# Altium schematic sheet Connector.SchDoc(Connector)
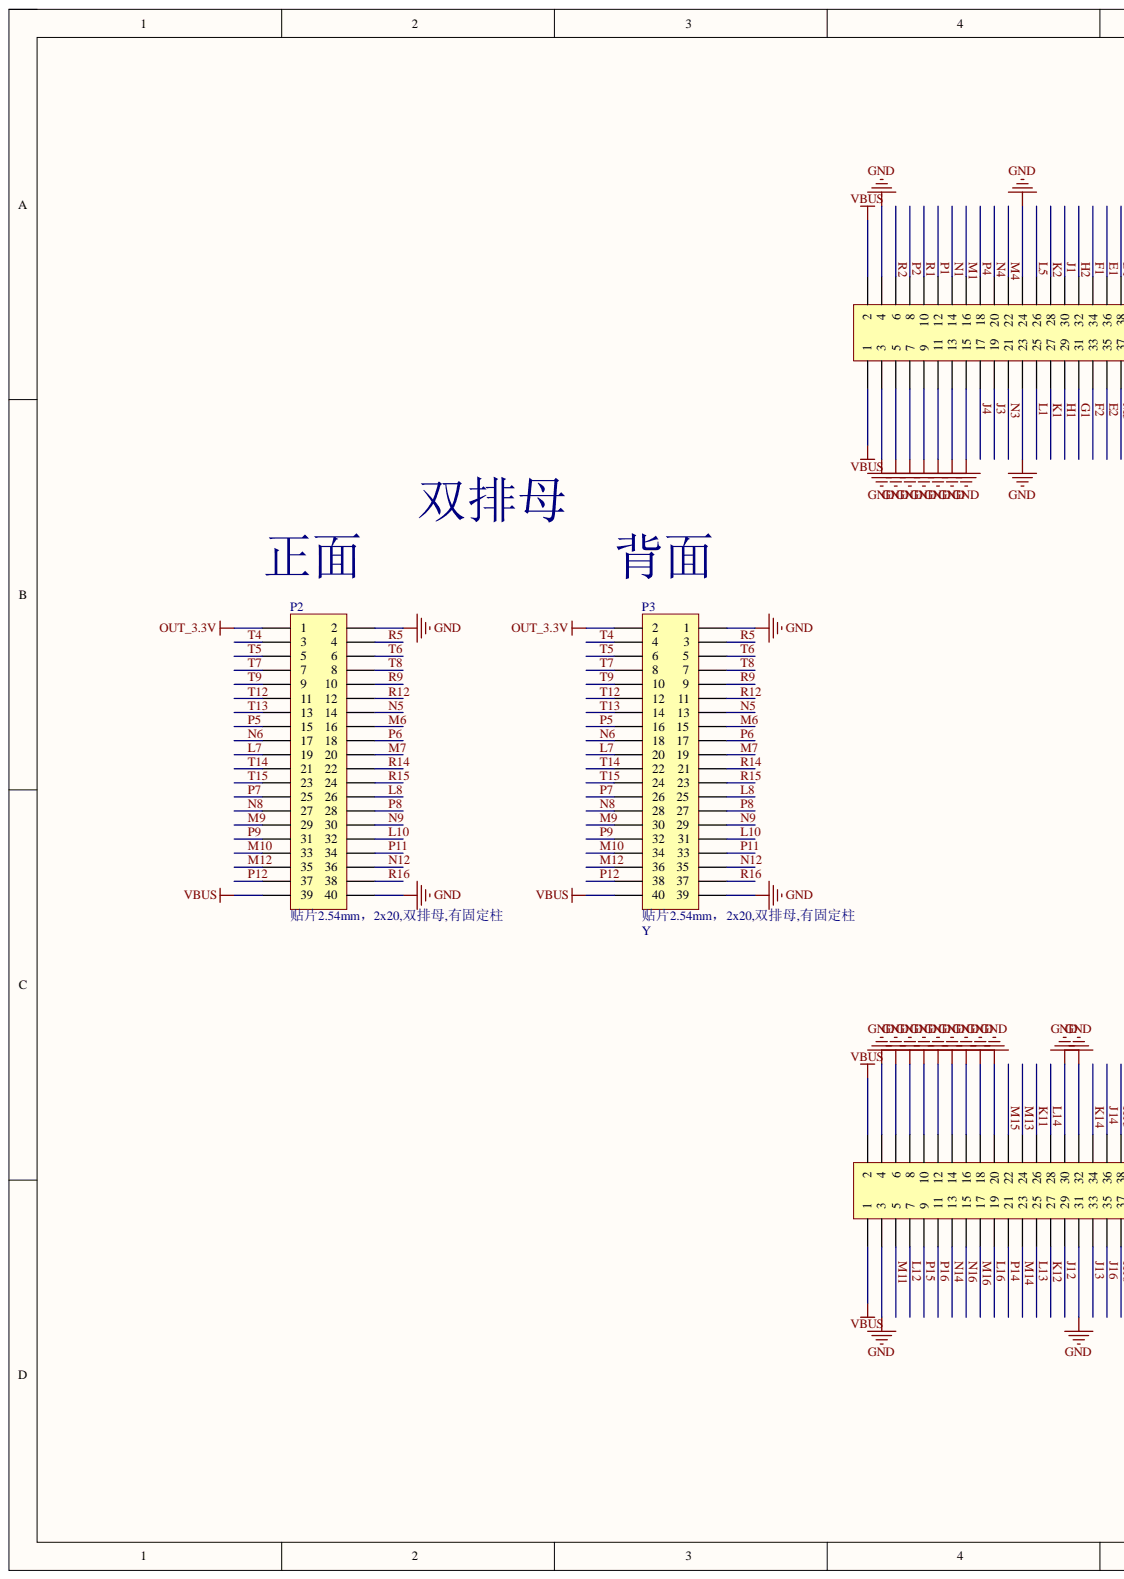
[diagram p0: Connector.SchDoc, left]
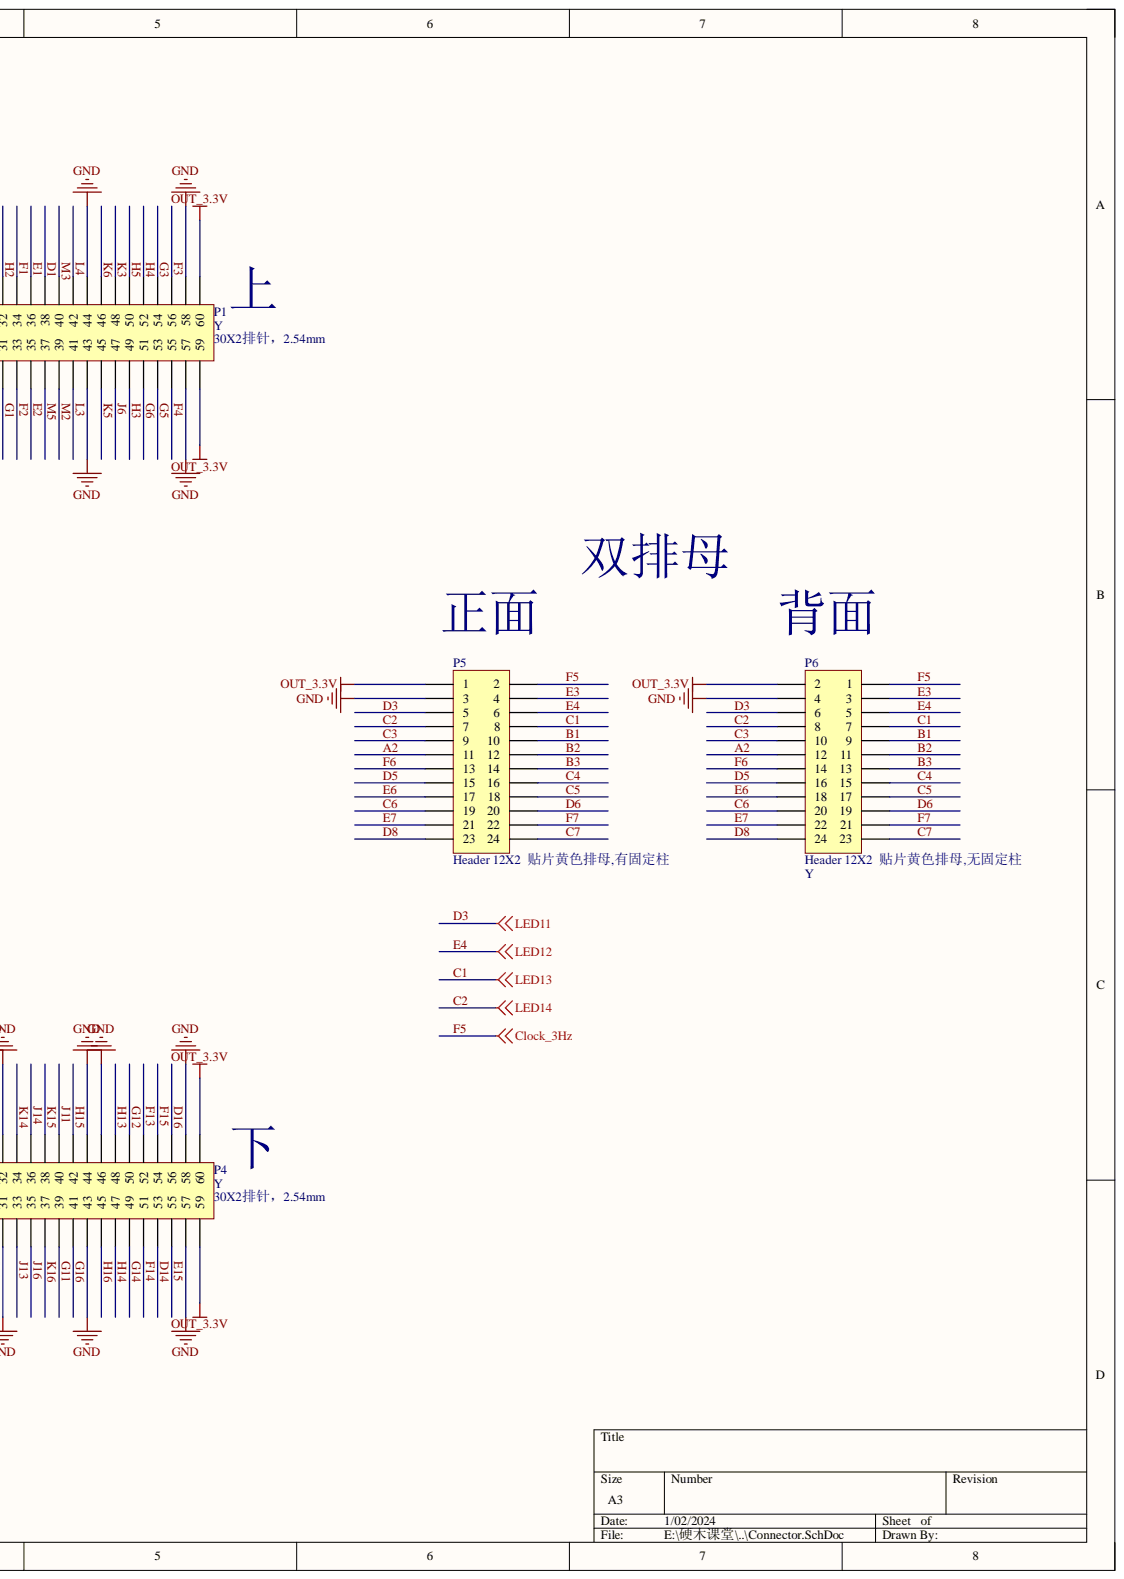
[diagram p1: Connector.SchDoc, right]

## Parts
P1  Y  [HDR2X30]
P2  贴片2.54mm，2x20,双排母,有固定柱  [DC3-40P-T-MIMI]
P3  Y  [DC3-40P-T-MIMI]
P4  Y  [HDR2X30]
P5  Header 12X2  贴片黄色排母,有固定柱  [YQ2*12-SMT]
P6  Y  [YQ2*12-SMT]

## Nets
GND: P1.3 P1.4 P1.5 P1.7 P1.9 P1.11 P1.13 P1.15 P1.23 P1.24 P1.43 P1.44 P1.57 P1.58 P2.2 P2.40 P3.1 P3.39 P4.3 P4.4 P4.6 P4.8 P4.10 P4.12 P4.14 P4.16 P4.18 P4.20 P4.30 P4.31 P4.32 P4.43 P4.44 P4.46 P4.57 P4.58 P5.3 P6.4
OUT_3.3V: P1.59 P1.60 P2.1 P3.2 P4.59 P4.60 P5.1 P6.2
VBUS: P1.1 P1.2 P2.39 P3.40 P4.1 P4.2
A2: P5.11 P6.12
B1: P5.10 P6.9
B2: P5.12 P6.11
B3: P5.14 P6.13
C1: P5.8 P6.7
C2: P5.7 P6.8
C3: P5.9 P6.10
C4: P5.16 P6.15
C5: P5.18 P6.17
C6: P5.19 P6.20
C7: P5.24 P6.23
D1: P1.38
D3: P5.5 P6.6
D5: P5.15 P6.16
D6: P5.20 P6.19
D8: P5.23 P6.24
D14: P4.53
D16: P4.56
E1: P1.36
E2: P1.35
E3: P5.4 P6.3
E4: P5.6 P6.5
E6: P5.17 P6.18
E7: P5.21 P6.22
E15: P4.55
F1: P1.34
F2: P1.33
F3: P1.56
F4: P1.55
F5: P5.2 P6.1
F6: P5.13 P6.14
F7: P5.22 P6.21
F13: P4.52
F14: P4.51
F15: P4.54
G1: P1.31
G3: P1.54
G5: P1.53
G6: P1.51
G11: P4.39
G12: P4.50
G14: P4.49
G16: P4.41
H1: P1.29
H2: P1.32
H3: P1.49
H4: P1.52
H5: P1.50
H13: P4.48
H14: P4.47
H15: P4.42
H16: P4.45
J1: P1.30
J3: P1.19
J4: P1.17
J6: P1.47
J11: P4.40
J12: P4.29
J13: P4.33
J14: P4.36
J16: P4.35
K1: P1.27
K2: P1.28
K3: P1.48
K5: P1.45
K6: P1.46
K11: P4.26
K12: P4.27
K14: P4.34
K15: P4.38
K16: P4.37
L1: P1.25
L3: P1.41
L4: P1.42
L5: P1.26
L7: P2.19 P3.20
L8: P2.26 P3.25
L10: P2.32 P3.31
L12: P4.7
L13: P4.25
L14: P4.28
L16: P4.19
M1: P1.16
M2: P1.39
M3: P1.40
M4: P1.22
M5: P1.37
M6: P2.16 P3.15
M7: P2.20 P3.19
M9: P2.29 P3.30
M10: P2.33 P3.34
M11: P4.5
M12: P2.35 P3.36
M13: P4.24
M14: P4.23
M15: P4.22
M16: P4.17
N1: P1.14
N3: P1.21
N4: P1.20
N5: P2.14 P3.13
N6: P2.17 P3.18
N8: P2.27 P3.28
N9: P2.30 P3.29
N12: P2.36 P3.35
N14: P4.13
N16: P4.15
P1: P1.12
P2: P1.8
P4: P1.18
P5: P2.15 P3.16
P6: P2.18 P3.17
P7: P2.25 P3.26
P8: P2.28 P3.27
P9: P2.31 P3.32
P11: P2.34 P3.33
P12: P2.37 P3.38
P14: P4.21
P15: P4.9
P16: P4.11
R1: P1.10
R2: P1.6
R5: P2.4 P3.3
R9: P2.10 P3.9
R12: P2.12 P3.11
R14: P2.22 P3.21
R15: P2.24 P3.23
R16: P2.38 P3.37
T4: P2.3 P3.4
T5: P2.5 P3.6
T6: P2.6 P3.5
T7: P2.7 P3.8
T8: P2.8 P3.7
T9: P2.9 P3.10
T12: P2.11 P3.12
T13: P2.13 P3.14
T14: P2.21 P3.22
T15: P2.23 P3.24

## Library data of the parts
P1 P4 = Header 30X2: Header, 30-Pin, Dual row30X2排针，2.54mm; Component Kind: Standard; IGNORE: Y; LatestRevisionDate: 17-Jul-2002; LatestRevisionNote: Re-released for DXP Platform.; Library Name: Miscellaneous Connectors.IntLib; Parameter: 30X2排针，2.54mm; Signal Integrity: Connector; Publisher: Altium Limited
P2 = Header 20X2: 贴片2.54mm，2x20,双排孔; Component Kind: Standard; LatestRevisionDate: 17-Jul-2002; LatestRevisionNote: Re-released for DXP Platform.; Library Name: Miscellaneous Connectors.IntLib; Signal Integrity: Connector; Publisher: Altium Limited
P3 = Header 20X2: 贴片2.54mm，2x20,双排孔; Parameter: 贴片2.54mm，2x20,双排母,有固定柱; Component Kind: Standard; IGNORE: Y; LatestRevisionDate: 17-Jul-2002; LatestRevisionNote: Re-released for DXP Platform.; Library Name: Miscellaneous Connectors.IntLib; Signal Integrity: Connector; Publisher: Altium Limited
P5 = Header 12X2: Header, 12-Pin, Dual row; Component Kind: Standard; LatestRevisionDate: 17-Jul-2002; LatestRevisionNote: Re-released for DXP Platform.; Library Name: Miscellaneous Connectors.IntLib; Signal Integrity: Connector; Publisher: Altium Limited
P6 = Header 12X2: Header, 12-Pin, Dual row; Parameter: Header 12X2  贴片黄色排母,无固定柱; Component Kind: Standard; IGNORE: Y; LatestRevisionDate: 17-Jul-2002; LatestRevisionNote: Re-released for DXP Platform.; Library Name: Miscellaneous Connectors.IntLib; Signal Integrity: Connector; Publisher: Altium Limited
